# Altium schematic sheet NRF52840.SchDoc
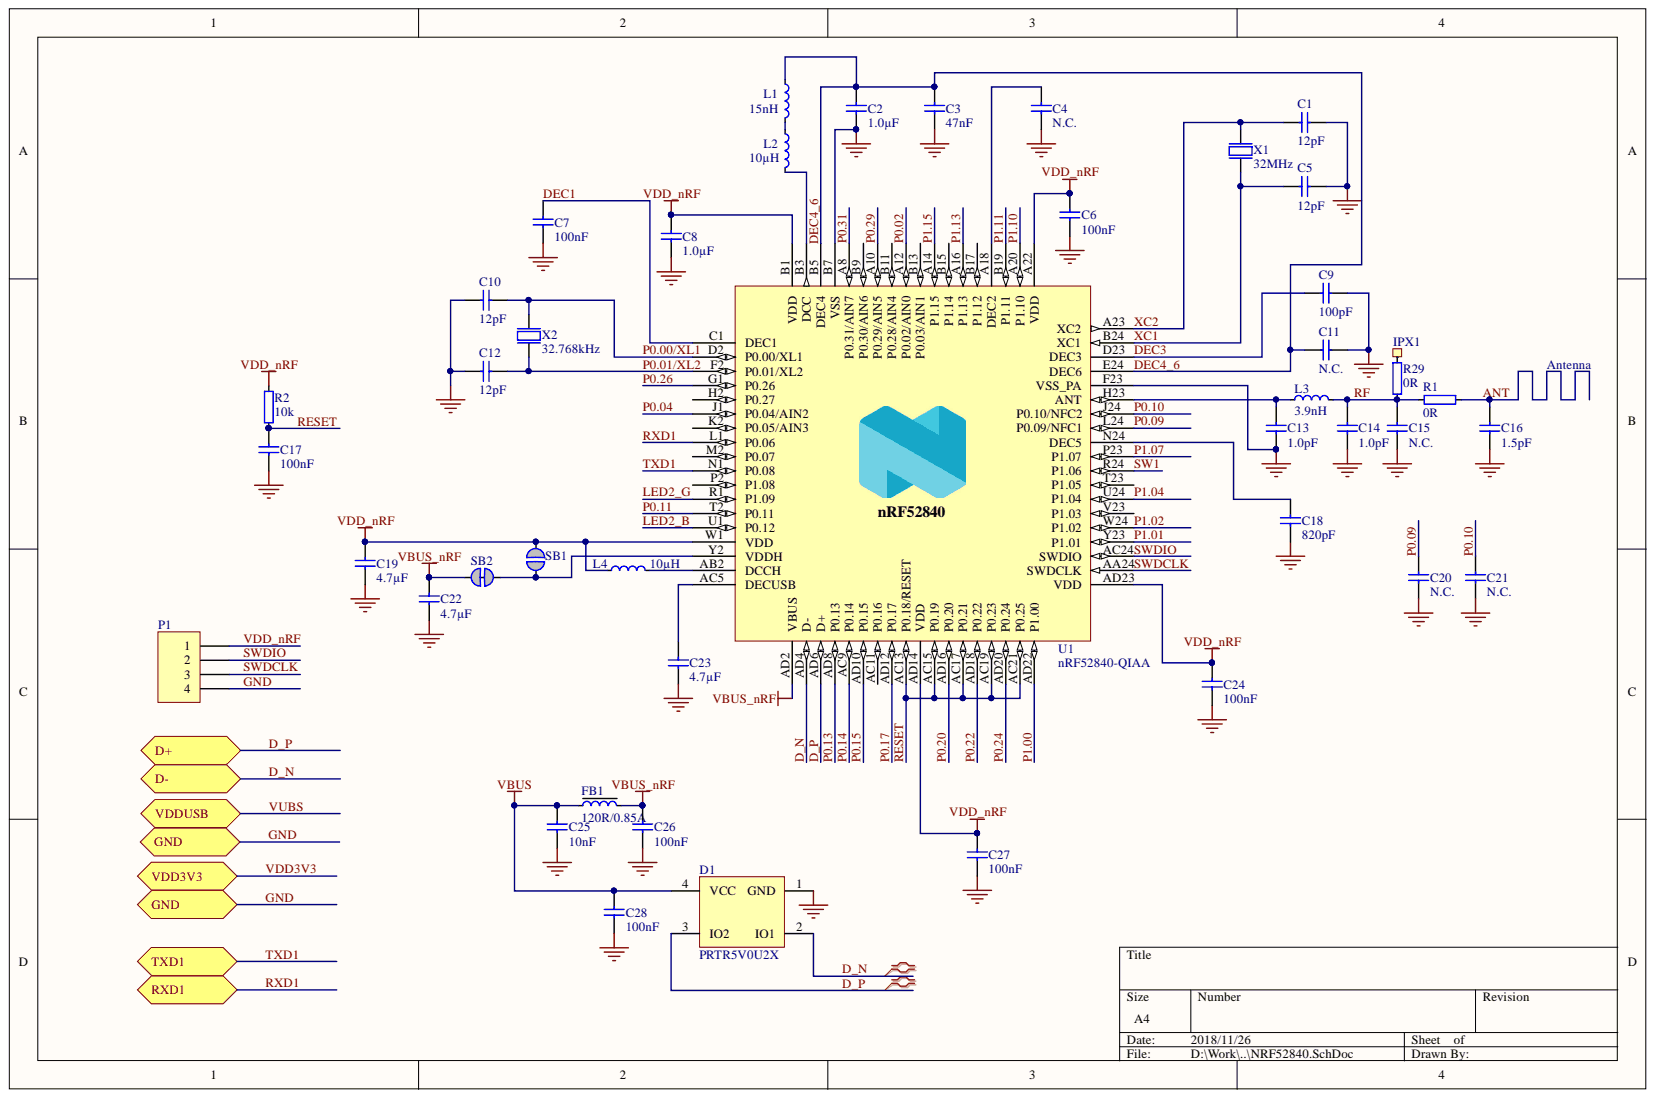
[diagram p0: NRF52840.SchDoc]

## Parts
C1  12pF  [CAPC0603X03L_C]
C2  1.0µF  [CAPC1608X06L]
C3  47nF  [CAPC0603X03L_C]
C4  N.C.  [CAPC0603X03L_C]
C5  12pF  [CAPC0603X03L_C]
C6  100nF  [CAPC0603X03L_C]
C7  100nF  [CAPC0603X03L_C]
C8  1.0µF  [CAPC1608X06L]
C9  100pF  [CAPC0603X03L_C]
C10  12pF  [CAPC0603X03L_C]
C11  N.C.  [CAPC0603X03L_C]
C12  12pF  [CAPC0603X03L_C]
C13  1.0pF  [CAPC0603X03L_C]
C14  1.0pF  [CAPC0603X03L_C]
C15  N.C.  [CAPC0603X03L_C]
C16  1.5pF  [CAPC0603X03L_C]
C17  100nF  [CAPC0603X03L_C]
C18  820pF  [CAPC0603X03L_C]
C19  4.7µF  [CAPC1608X06L]
C20  N.C.  [CAPC1005X04L]
C21  N.C.  [CAPC1005X04L]
C22  4.7µF  [CAPC1005X04L]
C23  4.7µF  [CAPC1608X06L]
C24  100nF  [CAPC0603X03L_C]
C25  10nF  [CAPC0603X03L_C]
C26  100nF  [CAPC0603X03L_C]
C27  100nF  [CAPC0603X03L_C]
C28  100nF  [CAPC0603X03L_C]
D1  PRTR5V0U2X  [SOT190P230X110-4L]
FB1  120R/0.85A  [INDC0603X03L_C]
IPX1  CH  [Castellated_Hole_0.9mm]  (value not drawn)  (no BOM)
L1  15nH  [INDC1005X04L]
L2  10µH  [INDC1608X06L]
L3  3.9nH  [INDC0603X03L_C]
L4  10µH  [INDC1608X06L]
P1  Header 4  [HDR1X4]  (value not drawn)
R1  0R  [RESC0603X03L_C]
R2  10k  [RESC0603X03L_C]
R29  0R  [RESC0603X03L_C]
SB1  Solderbridge  [Solderbridge]  (value not drawn)  (no BOM)
SB2  Solderbridge  [Solderbridge_Shorted]  (value not drawn)
U1  nRF52840-QIAA  [AQFN50P700X700X85_HS-74N]
X1  32MHz  [BT-XTAL_2016]
X2  32.768kHz  [XTAL_2012]

## Ports
D+, D-, GND, RXD1, TXD1, VDD3V3, VDDUSB

## Nets
VBUS: C25.2 C28.2 D1.4(VCC) FB1.1
VBUS_NRF: C22.1 C26.2 FB1.2 SB2.2 U1.AD2(VBUS)
ANT: C16.2 R1.2
D_N: D1.2(IO1) U1.AD4(D-)
D_P: D1.3(IO2) U1.AD6(D+)
DEC1: C7.1 U1.C1(DEC1)
DEC3: C9.1 U1.D23(DEC3)
DEC4_6: C2.2 C3.1 C11.2 L1.1 U1.B5(DEC4) U1.E24(DEC6)
GND: C1.2 C2.1 C3.2 C4.2 C5.2 C6.2 C7.2 C8.2 C9.2 C10.2 C11.1 C12.2 C13.1 C14.1 C15.2 C16.1 C17.1 C18.2 C19.2 C20.1 C21.2 C22.2 C23.2 C24.2 C25.1 C26.1 C27.2 C28.1 D1.1(GND) P1.4 U1.74 U1.B7(VSS) U1.F23(VSS_PA) X1.2 X1.4 ; port GND
LED2_B: U1.U1(P0.12)
LED2_G: U1.R1(P1.09)
P0.00/XL1: C10.1 U1.D2(P0.00/XL1) X2.1
P0.01/XL2: C12.1 U1.F2(P0.01/XL2) X2.2
P0.02: U1.A12(P0.02/AIN0)
P0.04: U1.J1(P0.04/AIN2)
P0.09: C20.2 U1.L24(P0.09/NFC1)
P0.10: C21.1 U1.J24(P0.10/NFC2)
P0.11: U1.T2(P0.11)
P0.13: U1.AD8(P0.13)
P0.14: U1.AC9(P0.14)
P0.15: U1.AD10(P0.15)
P0.17: U1.AD12(P0.17)
P0.20: U1.AD16(P0.20)
P0.22: U1.AD18(P0.22)
P0.24: U1.AD20(P0.24)
P0.26: U1.G1(P0.26)
P0.29: U1.A10(P0.29/AIN5)
P0.31: U1.A8(P0.31/AIN7)
P1.00: U1.AD22(P1.00)
P1.01: U1.Y23(P1.01)
P1.02: U1.W24(P1.02)
P1.04: U1.U24(P1.04)
P1.07: U1.P23(P1.07)
P1.10: U1.A20(P1.10)
P1.11: U1.B19(P1.11)
P1.13: U1.A16(P1.13)
P1.15: U1.A14(P1.15)
RESET: C17.2 R2.1 U1.AC13(P0.18/RESET) U1.AC15(P0.19) U1.AC17(P0.21) U1.AC19(P0.23) U1.AC21(P0.25)
RF: C14.2 C15.1 L3.2 R1.1
RXD1: U1.L1(P0.06) ; port RXD1
SW1: U1.R24(P1.06)
SWDCLK: P1.3 U1.AA24(SWDCLK)
SWDIO: P1.2 U1.AC24(SWDIO)
TXD1: U1.N1(P0.08) ; port TXD1
VDD_NRF: C6.1 C8.1 C19.1 C24.1 C27.1 L4.1 P1.1 R2.2 SB1.1 U1.A22(VDD) U1.AD14(VDD) U1.AD23(VDD) U1.B1(VDD) U1.W1(VDD)
XC1: C5.1 U1.B24(XC1) X1.1
XC2: C1.1 U1.A23(XC2) X1.3
NetC4_1: C4.1 U1.A18(DEC2)
NetC13_2: C13.2 L3.1 U1.H23(ANT)
NetC18_1: C18.1 U1.N24(DEC5)
NetC23_1: C23.1 U1.AC5(DECUSB)
NetIPX1_1: IPX1.1 R29.2
NetL1_2: L1.2 L2.1
NetL2_2: L2.2 U1.B3(DCC)
NetL4_2: L4.2 U1.AB2(DCCH)
NetSB1_2: SB1.2 SB2.1 U1.Y2(VDDH)
VDD3V3: ; port VDD3V3
VUBS: ; port VDDUSB

Not connected: R29 1; U1 AC11(P0.16) B9(P0.30/AIN6) B11(P0.28/AIN4) B13(P0.03/AIN1) B15(P1.14) B17(P1.12) H2(P0.27) K2(P0.05/AIN3) M2(P0.07) P2(P1.08) T23(P1.05) V23(P1.03)

## Library data of the parts
C1 C5 C10 C12 = C0201-12p: Capacitor, NP0, ±2%; Part number IPS: 00000007848; Artikkelnr Noca: N.A.; Database Table Name: Capacitors$; Note: 25V; Artikkelnr Simpro: N.A.; Component Kind: Standard; ComponentLink1Description: Datasheet; ComponentLink2Description: Supplier link; Footprint Doc: 0201; LatestRevisionDate: 2017-11-09; Library Name: Nordic Passive DB.DbLib; Part Number: C0201-12p; Pin Count: 2; Published: 2014-11-13; Value: 12pF; Publisher: Nordic Semiconductor
C2 C8 = C0603-1µ0: Capacitor, X7R, ±10%; Part number IPS: 00000000616; Artikkelnr Noca: 20039335; Artikkelnr Simpro: N.A.; Database Table Name: Capacitors$; Note: 16V; Component Kind: Standard; ComponentLink1Description: Datasheet; ComponentLink2Description: Supplier link; Footprint Doc: 0603; LatestRevisionDate: 2013-06-05; Library Name: Nordic Passive DB.DbLib; Part Number: C0603-1µ0; Pin Count: 2; Published: 2009-05-08; Value: 1.0µF; Publisher: Nordic Semiconductor
C3 = C0201-47n: Capacitor, X5R, ±10%; Part number IPS: 00000001250; Artikkelnr Noca: N.A.; Database Table Name: Capacitors$; Note: 10V; Artikkelnr Simpro: N.A.; Component Kind: Standard; ComponentLink1Description: Datasheet; ComponentLink2Description: Supplier link; Footprint Doc: 0201; LatestRevisionDate: 2017-11-09; Library Name: Nordic Passive DB.DbLib; Part Number: C0201-47n; Pin Count: 2; Published: 2014-11-13; Value: 47nF; Publisher: Nordic Semiconductor
C4 C11 C15 = C0201-N.C.: Not mounted; Artikkelnr Noca: N.A.; Assembly: Not mounted; Database Table Name: Capacitors$; Note: Chip Cap; Artikkelnr Simpro: N.A.; Component Kind: Standard; ComponentLink1Description: Datasheet; ComponentLink2Description: Supplier link; Footprint Doc: 0201; LatestRevisionDate: 2014-11-13; Library Name: Nordic Passive DB.DbLib; Part Number: C0201-N.C.; Pin Count: 2; Published: 2014-11-13; Value: N.C.; Publisher: Nordic Semiconductor
C6 C7 C17 C24 C26-C28 = C0201-100n: Capacitor, X5R, ±10%; Part number IPS: 00000001248; Artikkelnr Noca: N.A.; Database Table Name: Capacitors$; Note: 16V; Artikkelnr Simpro: N.A.; Component Kind: Standard; ComponentLink1Description: Datasheet; ComponentLink2Description: Supplier link; Footprint Doc: 0201; LatestRevisionDate: 2017-11-09; Library Name: Nordic Passive DB.DbLib; Part Number: C0201-100n; Pin Count: 2; Published: 2014-11-13; Value: 100nF; Publisher: Nordic Semiconductor
C9 = C0201-100p: Capacitor, NP0, ±2%; Part number IPS: 00000007853; Artikkelnr Noca: N.A.; Database Table Name: Capacitors$; Note: 25V; Artikkelnr Simpro: N.A.; Component Kind: Standard; ComponentLink1Description: Datasheet; ComponentLink2Description: Supplier link; Footprint Doc: 0201; LatestRevisionDate: 2017-11-09; Library Name: Nordic Passive DB.DbLib; Part Number: C0201-100p; Pin Count: 2; Published: 2014-11-13; Value: 100pF; Publisher: Nordic Semiconductor
C13 C14 = C0201-1.0p: Capacitor, NP0, ±5%; Part number IPS: 00000001247; Artikkelnr Noca: N.A.; Database Table Name: Capacitors$; Note: 50V; Artikkelnr Simpro: N.A.; Component Kind: Standard; ComponentLink1Description: Datasheet; ComponentLink2Description: Supplier link; Footprint Doc: 0201; LatestRevisionDate: 2017-11-09; Library Name: Nordic Passive DB.DbLib; Part Number: C0201-1.0p; Pin Count: 2; Published: 2014-11-13; Value: 1.0pF; Publisher: Nordic Semiconductor
C16 = C0201-1.5p: Capacitor, NP0, ±0.1pF; Part number IPS: 00000007107; Artikkelnr Noca: N.A.; Database Table Name: Capacitors$; Note: 50V; Artikkelnr Simpro: N.A.; Component Kind: Standard; ComponentLink1Description: Datasheet; ComponentLink2Description: Supplier link; Footprint Doc: 0201; LatestRevisionDate: 2017-11-09; Library Name: Nordic Passive DB.DbLib; Part Number: C0201-1.5p; Pin Count: 2; Published: 2014-11-13; Value: 1.5pF; Publisher: Nordic Semiconductor
C18 = C0201-820p: Capacitor, X7R, ±10%; Part number IPS: 00000001249; Artikkelnr Noca: N.A.; Database Table Name: Capacitors$; Note: 25V; Artikkelnr Simpro: N.A.; Component Kind: Standard; ComponentLink1Description: Datasheet; ComponentLink2Description: Supplier link; Footprint Doc: 0201; LatestRevisionDate: 2017-11-09; Library Name: Nordic Passive DB.DbLib; Part Number: C0201-820p; Pin Count: 2; Published: 2014-11-13; Value: 820pF; Publisher: Nordic Semiconductor
C19 C23 = C0603-4µ7: Capacitor, X5R, ±10%; Part number IPS: 00000007783; Artikkelnr Noca: 20069060; Artikkelnr Simpro: N.A.; Database Table Name: Capacitors$; Note: 6.3V; Component Kind: Standard; ComponentLink1Description: Datasheet; ComponentLink2Description: Supplier link; Footprint Doc: 0603; LatestRevisionDate: 2009-11-23; Library Name: Nordic Passive DB.DbLib; Part Number: C0603-4µ7; Pin Count: 2; Published: 2009-05-08; Value: 4.7µF; Publisher: Nordic Semiconductor
C20 C21 = C0402-N.C.: Not mounted; Artikkelnr Noca: N.A.; Assembly: Not mounted; Database Table Name: Capacitors$; Note: Chip Cap; Artikkelnr Simpro: N.A.; Component Kind: Standard; ComponentLink1Description: Datasheet; ComponentLink2Description: Supplier link; Footprint Doc: 0402; LatestRevisionDate: 2009-05-08; Library Name: Nordic Passive DB.DbLib; Part Number: C0402-N.C.; Pin Count: 2; Published: 2009-05-08; Value: N.C.; Publisher: Nordic Semiconductor
C22 = C0402-4µ7: Capacitor, X5R, ±20%; Part number IPS: 00000001251; Artikkelnr Noca: 20039482; Artikkelnr Simpro: N.A.; Database Table Name: Capacitors$; Note: 10V; Component Kind: Standard; ComponentLink1Description: Datasheet; ComponentLink2Description: Supplier link; Footprint Doc: 0402; LatestRevisionDate: 2017-11-09; Library Name: Nordic Passive DB.DbLib; Part Number: C0402-4µ7; Pin Count: 2; Published: 2009-05-08; Supplier: Digi-Key; Supplier part number: 490-13252-1-ND; Value: 4.7µF; Publisher: Nordic Semiconductor
C25 = C0201-10n: Capacitor, X7R, ±10%; Part number IPS: 00000003480; Artikkelnr Noca: N.A.; Database Table Name: Capacitors$; Note: 10V; Artikkelnr Simpro: N.A.; Component Kind: Standard; ComponentLink1Description: Datasheet; ComponentLink2Description: Supplier link; Footprint Doc: 0201; LatestRevisionDate: 2017-11-09; Library Name: Nordic Passive DB.DbLib; Part Number: C0201-10n; Pin Count: 2; Published: 2014-11-13; Value: 10nF; Publisher: Nordic Semiconductor
D1 = PRTR5V0U2X: Ultra low capacitance double rail-to-rail ESD protection diode; 2nd source part number: 152-4157; Manufacturer: NXP; Part number IPS: 00000009951; Artikkelnr Noca: 10069015; Artikkelnr Simpro: N.A.; Database Table Name: Semiconductors$; 2nd source Supplier: Farnell; Component Kind: Standard; ComponentLink1Description: Datasheet; ComponentLink2Description: Supplier link; Footprint Doc: SOT-143B; HelpURL: http://www.farnell.com/datasheets/109718.pdf; LatestRevisionDate: 2017-11-09; Library Name: Nordic Discrete DB.DbLib; Manufacturer Part Number: PRTR5V0U2X; Part Number: PRTR5V0U2X; Pin Count: 4; Published: 2012-06-11; Supplier: Digi-Key; Supplier part number: 568-4140-1-ND; Value: PRTR5V0U2X; Publisher: Nordic Semiconductor
FB1 = FB0201-120R@100M-850mA: Ferrite Bead, 120 Ohm @ 100MHz, 850mA, 150 mOhm Max; Manufacturer: Taiyo Yuden; Manufacturer Part Number: BKP0603HS121-T; Part number IPS: 00000001252; Artikkelnr Noca: N.A.; Database Table Name: Inductors$; Note: Chip EMIFIL Inductor Type Chip Ferrite Bead; Artikkelnr Simpro: N.A.; Component Kind: Standard; ComponentLink1Description: Datasheet; ComponentLink2Description: Supplier link; Footprint Doc: 0402; HelpURL: http://ds.yuden.co.jp/TYCOMPAS/ut/detail.do?productNo=BKP0603HS121-T&fileName=BKP0603HS121-T_SS&mode=specSheetDownload; LatestRevisionDate: 2016-08-23; Library Name: Nordic Passive DB.DbLib; Part Number: FB0201-120R@100M-850mA; Pin Count: 2; Published: 2016-08-23; Supplier: Digi-Key; Supplier part number: 587-3286-1-ND; Value: 120R/0.85A; Publisher: Nordic Semiconductor
IPX1 = Castellated Hole 0.9mm: Artikkelnr Noca: N.A.; Database Table Name: Connectors$; Artikkelnr Simpro: N.A.; ComponentLink1Description: Datasheet; ComponentLink2Description: Supplier link; Description: Castellated Hole 0.9mm; LatestRevisionDate: 2016-08-22; Library Name: Nordic Passive DB.DbLib; Part Number: Castellated Hole 0.9mm; Pin Count: 1; Published: 2016-08-22; Value: CH; Publisher: Nordic Semiconductor
L1 = L0402-15n: Inductor, 320mA, ±5%; 2nd source part number: 658-1328; Manufacturer: Taiyo Yuden; Manufacturer Part Number: HK100515NJ-T; Part number IPS: 00000001262; Artikkelnr Noca: 33029323; Artikkelnr Simpro: N.A.; Database Table Name: Inductors$; Note: High frequency chip inductor ±10%; 2nd source Supplier: Farnell; Component Kind: Standard; ComponentLink1Description: Datasheet; ComponentLink2Description: Supplier link; Footprint Doc: 0402; HelpURL: http://www.yuden.co.jp/productdata/catalog/en/mlci04_e.pdf; LatestRevisionDate: 2012-09-19; Library Name: Nordic Passive DB.DbLib; Part Number: L0402-15n; Pin Count: 2; Published: 2010-07-12; Supplier: Digi-Key; Supplier part number: 587-1521-1-ND; Value: 15nH; Publisher: Nordic Semiconductor
L2 L4 = L0603-10µ: Inductor, 80mA, ±10%; 2nd source part number: 178-6105; Manufacturer: Taiyo Yuden; Manufacturer Part Number: LBMF1608T100K; Part number IPS: 00000007794; Artikkelnr Noca: 33029314; Artikkelnr Simpro: N.A.; Database Table Name: Inductors$; Note: LBMF1608T100K 10µH 80mA 0603; 2nd source Supplier: Farnell; Component Kind: Standard; ComponentLink1Description: Datasheet; ComponentLink2Description: Supplier link; Footprint Doc: 0603; HelpURL: http://www.yuden.co.jp/ut/product/pdf/elb2_e.pdf; LatestRevisionDate: 2012-09-19; Library Name: Nordic Passive DB.DbLib; Part Number: L0603-10µ; Pin Count: 2; Published: 2010-02-24; Supplier: Digi-Key; Supplier part number: 587-1714-1-ND; Value: 10µH; Publisher: Nordic Semiconductor
L3 = L0201-3n9: Inductor, 400mA, ±0.1nH; Part number IPS: 00000001253; Artikkelnr Noca: N.A.; Database Table Name: Inductors$; Note: High frequency chip inductor ±5%; Artikkelnr Simpro: N.A.; Component Kind: Standard; ComponentLink1Description: Datasheet; ComponentLink2Description: Supplier link; Footprint Doc: 0201; LatestRevisionDate: 2017-11-09; Library Name: Nordic Passive DB.DbLib; Part Number: L0201-3n9; Pin Count: 2; Published: 2013-04-10; Value: 3.9nH; Publisher: Nordic Semiconductor
P1 = Header 4: Header, 4-Pin; Component Kind: Standard; LatestRevisionDate: 17-Jul-2002; LatestRevisionNote: Re-released for DXP Platform.; Library Name: Miscellaneous Connectors.IntLib; Signal Integrity: Connector; Publisher: Altium Limited
R1 R29 = R0201-0R: Resistor, ±5%, 0.05W; Part number IPS: 00000003245; Artikkelnr Noca: N.A.; Database Table Name: Resistors$; Note: Chip Res 5%; Value Ohm: 0; Artikkelnr Simpro: N.A.; Component Kind: Standard; ComponentLink1Description: Datasheet; ComponentLink2Description: Supplier link; Footprint Doc: 0201; LatestRevisionDate: 2017-11-09; Library Name: Nordic Passive DB.DbLib; Part Number: R0201-0R; Pin Count: 2; Published: 2014-11-13; Value: 0R; Publisher: Nordic Semiconductor
R2 = R0201-10k: Resistor, ±1%, 0.05W; Part number IPS: 00000001255; Artikkelnr Noca: N.A.; Database Table Name: Resistors$; Note: Chip Res 1%; Value Ohm: 10000; Artikkelnr Simpro: N.A.; Component Kind: Standard; ComponentLink1Description: Datasheet; ComponentLink2Description: Supplier link; Footprint Doc: 0201; LatestRevisionDate: 2014-11-13; Library Name: Nordic Passive DB.DbLib; Part Number: R0201-10k; Pin Count: 2; Published: 2014-11-13; Value: 10k; Publisher: Nordic Semiconductor
SB1 = Solderbridge: Artikkelnr Noca: N.A.; Database Table Name: Connectors$; Artikkelnr Simpro: N.A.; ComponentLink1Description: Datasheet; ComponentLink2Description: Supplier link; Description: Solderbridge; Footprint Doc: Solderbridge; LatestRevisionDate: 2009-12-14; Library Name: Nordic Passive DB.DbLib; Part Number: Solderbridge; Pin Count: 2; Published: 2009-12-14; Value: Solderbridge; Publisher: Nordic Semiconductor
SB2 = Solderbridge Shorted: Shorted Solderbridge; Artikkelnr Noca: N.A.; Database Table Name: Connectors$; Artikkelnr Simpro: N.A.; Component Kind: Net Tie; ComponentLink1Description: Datasheet; ComponentLink2Description: Supplier link; Footprint Doc: Solderbridge_Shorted; LatestRevisionDate: 2012-08-07; Library Name: Nordic Passive DB.DbLib; Part Number: Solderbridge Shorted; Pin Count: 2; Published: 2012-08-07; Value: Solderbridge; Publisher: Nordic Semiconductor
U1 = nRF52840-QIAAC0: Multi-protocol Bluetooth Low Energy, IEEE 802.15.4, ANT and 2.4GHz proprietary system-on-chip; Manufacturer: Nordic Semiconductor; Artikkelnr Noca: 15079380; Artikkelnr Simpro: N.A.; Database Table Name: nRF Devices$; Component Kind: Standard; ComponentLink1Description: Datasheet; ComponentLink2Description: Supplier link; Footprint Doc: AQFN-73; LatestRevisionDate: 2018-02-27; Library Name: Nordic Active DB.DbLib; Manufacturer Part Number: nRF52840-QIAAC0; Part Number: nRF52840-QIAAC0; Part number IPS: N.A.; Pin Count: 73; Published: 2017-08-21; Supplier: Nordic Semiconductor; Supplier part number: nRF52840-QIAAC0; Value: nRF52840-QIAA; Publisher: Nordic Semiconductor
X1 = XTAL_SMD2016_32MHz_8pF_Murata: XTAL SMD 2016, 32MHz, Cl=8pF, Tol: ±10ppm, Stab: ±10ppm; Manufacturer: Murata; Manufacturer Part Number: XRCGB32M000F1H01R0; Part number IPS: 80000001424; Artikkelnr Noca: N.A.; Database Table Name: Crystal_Osc$; Note: XTAL SMD 32.000MHz; Artikkelnr Simpro: N.A.; Component Kind: Standard; ComponentLink1Description: Datasheet; ComponentLink2Description: Supplier link; Footprint Doc: XTAL_2016; HelpURL: http://www.murata.com/en-global/products/productdetail.aspx?cate=cgsubCrystalUnits&partno=XRCGB32M000F1H01R0; LatestRevisionDate: 2017-11-09; Library Name: Nordic Discrete DB.DbLib; Part Number: XTAL_SMD2016_32MHz_8pF_Murata; Pin Count: 4; Published: 2016-10-26; Value: 32MHz; Publisher: Nordic Semiconductor
X2 = XTAL_SMD2012_32.768kHz_9pF_Seiko: XTAL SMD 2012, 32.768kHz, 9pF, ±20ppm; Manufacturer: Seiko; Manufacturer Part Number: SC20S-9.0PF20PPM; Part number IPS: 80000001425; Artikkelnr Noca: N.A.; Database Table Name: Crystal_Osc$; Note: XTAL SMD 32.768kHz; Artikkelnr Simpro: N.A.; Component Kind: Standard; ComponentLink1Description: Datasheet; ComponentLink2Description: Supplier link; Footprint Doc: XTAL_2012; HelpURL: http://www.sii.co.jp/en/quartz/files/2013/03/file_PRODUCT_MASTER_50811_GRAPHIC03.pdf; LatestRevisionDate: 2017-11-09; Library Name: Nordic Discrete DB.DbLib; Part Number: XTAL_SMD2012_32.768kHz_9pF_Seiko; Pin Count: 2; Published: 2015-10-15; Value: 32.768kHz; Publisher: Nordic Semiconductor
